# Altium schematic sheet MCU.SchDoc
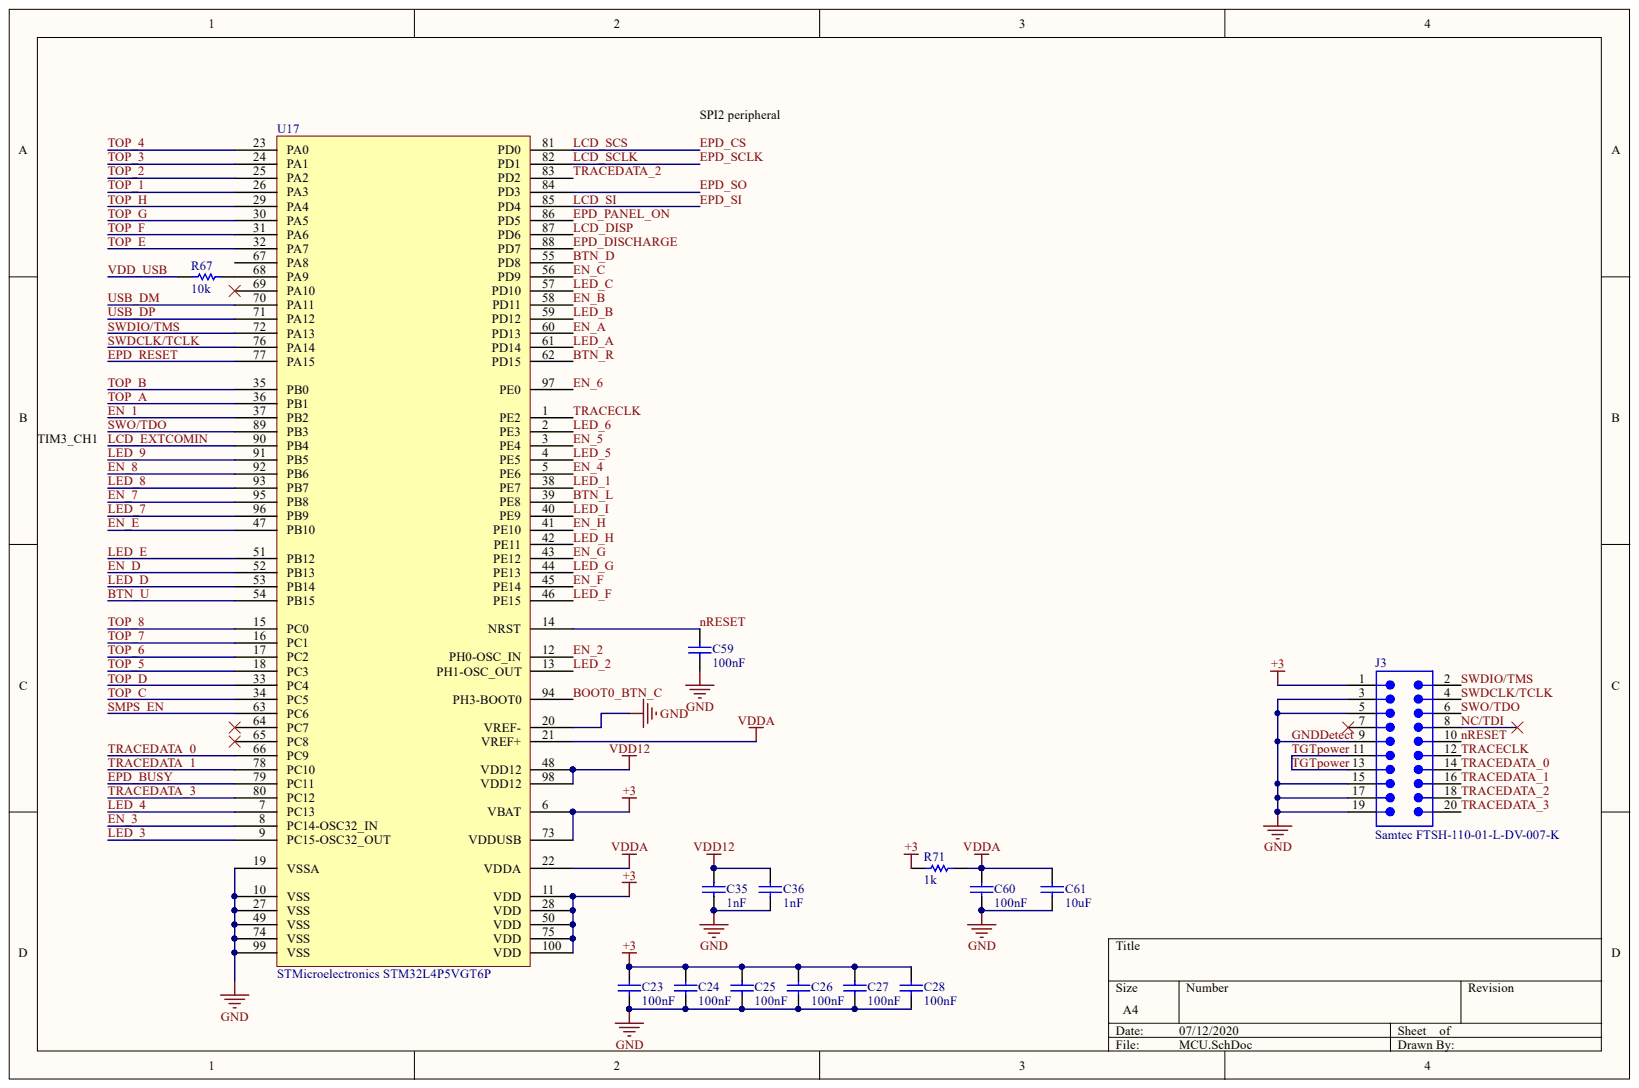
[diagram p0: MCU.SchDoc]

## Parts
C23  100nF  [PCB-srj5nzvxxiuyr0ufdy6u-1]
C24  100nF  [PCB-srj5nzvxxiuyr0ufdy6u-1]
C25  100nF  [PCB-srj5nzvxxiuyr0ufdy6u-1]
C26  100nF  [PCB-srj5nzvxxiuyr0ufdy6u-1]
C27  100nF  [PCB-srj5nzvxxiuyr0ufdy6u-1]
C28  100nF  [PCB-srj5nzvxxiuyr0ufdy6u-1]
C35  1nF  [PCB-srj5nzvxxiuyr0ufdy6u-1]
C36  1nF  [PCB-srj5nzvxxiuyr0ufdy6u-1]
C59  100nF  [PCB-srj5nzvxxiuyr0ufdy6u-1]
C60  100nF  [PCB-srj5nzvxxiuyr0ufdy6u-1]
C61  10uF  [CAPC1608X08N]
J3  Samtec FTSH-110-01-L-DV-007-K  [PCB-m5awqejvlawzn2tj7o8m-1]
R67  10k  [RESC1005X04M]
R71  1k  [RESC1005X04M]
U17  STMicroelectronics STM32L4P5VGT6P  Manufacturer Part Number: STM32L4P5VGT6P  [PCB-vk6j3i550t86uhup3vw7-1]

## Nets
+3: C23.2 C24.2 C25.2 C26.2 C27.2 C28.2 J3.1 R71.1 U17.6(VBAT) U17.11(VDD) U17.28(VDD) U17.50(VDD) U17.73(VDDUSB) U17.75(VDD) U17.100(VDD)
VDD12: C35.2 C36.2 U17.48(VDD12) U17.98(VDD12)
VDDA: C60.2 C61.2 R71.2 U17.21(VREF+) U17.22(VDDA)
BOOT0_BTN_C: U17.94(PH3-BOOT0)
BTN_D: U17.55(PD8)
BTN_L: U17.39(PE8)
BTN_R: U17.62(PD15)
BTN_U: U17.54(PB15)
EN_1: U17.37(PB2)
EN_2: U17.12(PH0-OSC_IN)
EN_3: U17.8(PC14-OSC32_IN)
EN_4: U17.5(PE6)
EN_5: U17.3(PE4)
EN_6: U17.97(PE0)
EN_7: U17.95(PB8)
EN_8: U17.92(PB6)
EN_A: U17.60(PD13)
EN_B: U17.58(PD11)
EN_C: U17.56(PD9)
EN_D: U17.52(PB13)
EN_E: U17.47(PB10)
EN_F: U17.45(PE14)
EN_G: U17.43(PE12)
EN_H: U17.41(PE10)
EPD_BUSY: U17.79(PC11)
EPD_CS: U17.81(PD0)
EPD_DISCHARGE: U17.88(PD7)
EPD_PANEL_ON: U17.86(PD5)
EPD_RESET: U17.77(PA15)
EPD_SCLK: U17.82(PD1)
EPD_SI: U17.85(PD4)
EPD_SO: U17.84(PD3)
GNDDETECT: C23.1 C24.1 C25.1 C26.1 C27.1 C28.1 C35.1 C36.1 C59.1 C60.1 C61.1 J3.3 J3.5 J3.9 J3.15 J3.17 J3.19 U17.10(VSS) U17.19(VSSA) U17.20(VREF-) U17.27(VSS) U17.49(VSS) U17.74(VSS) U17.99(VSS)
LCD_DISP: U17.87(PD6)
LCD_EXTCOMIN: U17.90(PB4)
LED_1: U17.38(PE7)
LED_2: U17.13(PH1-OSC_OUT)
LED_3: U17.9(PC15-OSC32_OUT)
LED_4: U17.7(PC13)
LED_5: U17.4(PE5)
LED_6: U17.2(PE3)
LED_7: U17.96(PB9)
LED_8: U17.93(PB7)
LED_9: U17.91(PB5)
LED_A: U17.61(PD14)
LED_B: U17.59(PD12)
LED_C: U17.57(PD10)
LED_D: U17.53(PB14)
LED_E: U17.51(PB12)
LED_F: U17.46(PE15)
LED_G: U17.44(PE13)
LED_H: U17.42(PE11)
LED_I: U17.40(PE9)
NC/TDI: J3.8
NRESET: C59.2 J3.10 U17.14(NRST)
SMPS_EN: U17.63(PC6)
SWDCLK/TCLK: J3.4 U17.76(PA14)
SWDIO/TMS: J3.2 U17.72(PA13)
SWO/TDO: J3.6 U17.89(PB3)
TGTPOWER: J3.11 J3.13
TOP_1: U17.26(PA3)
TOP_2: U17.25(PA2)
TOP_3: U17.24(PA1)
TOP_4: U17.23(PA0)
TOP_5: U17.18(PC3)
TOP_6: U17.17(PC2)
TOP_7: U17.16(PC1)
TOP_8: U17.15(PC0)
TOP_A: U17.36(PB1)
TOP_B: U17.35(PB0)
TOP_C: U17.34(PC5)
TOP_D: U17.33(PC4)
TOP_E: U17.32(PA7)
TOP_F: U17.31(PA6)
TOP_G: U17.30(PA5)
TOP_H: U17.29(PA4)
TRACECLK: J3.12 U17.1(PE2)
TRACEDATA_0: J3.14 U17.66(PC9)
TRACEDATA_1: J3.16 U17.78(PC10)
TRACEDATA_2: J3.18 U17.83(PD2)
TRACEDATA_3: J3.20 U17.80(PC12)
USB_DM: U17.70(PA11)
USB_DP: U17.71(PA12)
VDD_USB: R67.2
NetR67_1: R67.1 U17.68(PA9)

Not connected: J3 7; U17 64(PC7) 65(PC8) 67(PA8) 69(PA10)

## Library data of the parts
C23-C28 C59 C60 = CMP-16527024-7: 0402 100nF 6.3V X5R; Capacitance Tolerance: ±10%; Lead-Free Status: Lead Free; Voltage Rating (DC): 16.0 V; Capacitance: 100 nF; Case/Package: 0402; Component Kind: Standard; Dielectric Characteristic: X5R; Library Name: CommunityVault; Mounting Style: Surface Mount; Number of Pins: 2; OctopartID: 6877a17fb5d5b7b0; Operating Temperature: -55.0 °C to 85.0 °C; Packaging: Cut Tape (CT), Reel, Tape & Reel (TR); REACH SVHC Compliance: No SVHC; RoHS: Compliant; Size-Height: 560 µm; Size-Length: 1.00 mm; Size-Width: 500 µm
C35 C36 = CMP-16527024-7: 0402 1nF 6.3V X5R; Capacitance: 100 nF; Capacitance Tolerance: ±10%; Lead-Free Status: Lead Free; Voltage Rating (DC): 16.0 V; Case/Package: 0402; Component Kind: Standard; Dielectric Characteristic: X5R; Library Name: CommunityVault; Mounting Style: Surface Mount; Number of Pins: 2; OctopartID: 6877a17fb5d5b7b0; Operating Temperature: -55.0 °C to 85.0 °C; Packaging: Cut Tape (CT), Reel, Tape & Reel (TR); REACH SVHC Compliance: No SVHC; RoHS: Compliant; Size-Height: 560 µm; Size-Length: 1.00 mm; Size-Width: 500 µm
C61 = CMP-nocyn7nhex2srcneo663-1: 0603 10uF 6.3V X5R; Body Height: 0.8mm; Body Length: 1.6mm; Capacitance: 100nF; Package (Imperial): 0603; Package (Metric): 1608; Tolerance: 10%; Body Width: 0.8mm; Component Kind: Standard; CustomComponentID: nocyn7nhex2srcneo663; Library Name: CommunityVault
J3 = FTSH-110-01-L-DV-007-K: Conn Shrouded Header HDR 20 POS 1.27mm Solder ST SMD Tube; Component Kind: Standard; Library Name: Octopart library; Manufacturer Part Number: FTSH-110-01-L-DV-007-K; OctopartID: 8993427f2c465654; Octopart URL: http://octopart.com/ftsh-110-01-l-dv-007-k-samtec-48660659
R67 = CMP-v99hy84itq0asdedzg70-1: 0402 10k 5%; Body Height: 0.35mm; Body Length: 1mm; Body Width: 0.5mm; Package (Imperial): 0402; Package (Metric): 01005; Resistance: 10K?; Tolerance: 1%; Component Kind: Standard; CustomComponentID: v99hy84itq0asdedzg70; Library Name: CommunityVault
R71 = CMP-v99hy84itq0asdedzg70-1: 0402 1k 5%; Body Height: 0.35mm; Body Length: 1mm; Body Width: 0.5mm; Package (Imperial): 0402; Package (Metric): 01005; Resistance: 10K?; Tolerance: 1%; Component Kind: Standard; CustomComponentID: v99hy84itq0asdedzg70; Library Name: CommunityVault
U17 = CMP-2c23e34d780af3e4-2: MCU 32-Bit ARM Cortex-M4F RISC 1MB Flash 1.71V to 3.6V 100-Pin LQFP Tray; Component Kind: Standard; Library Name: FavoritesLibrary; Lifecycle Status: Not Listed by Manufacturer; OctopartID: 2c23e34d780af3e4; Octopart URL: https://octopart.com/stm32l4p5vgt6p-stmicroelectronics-107245903
